# Altium schematic sheet SH_SW20_V1.0.SchDoc
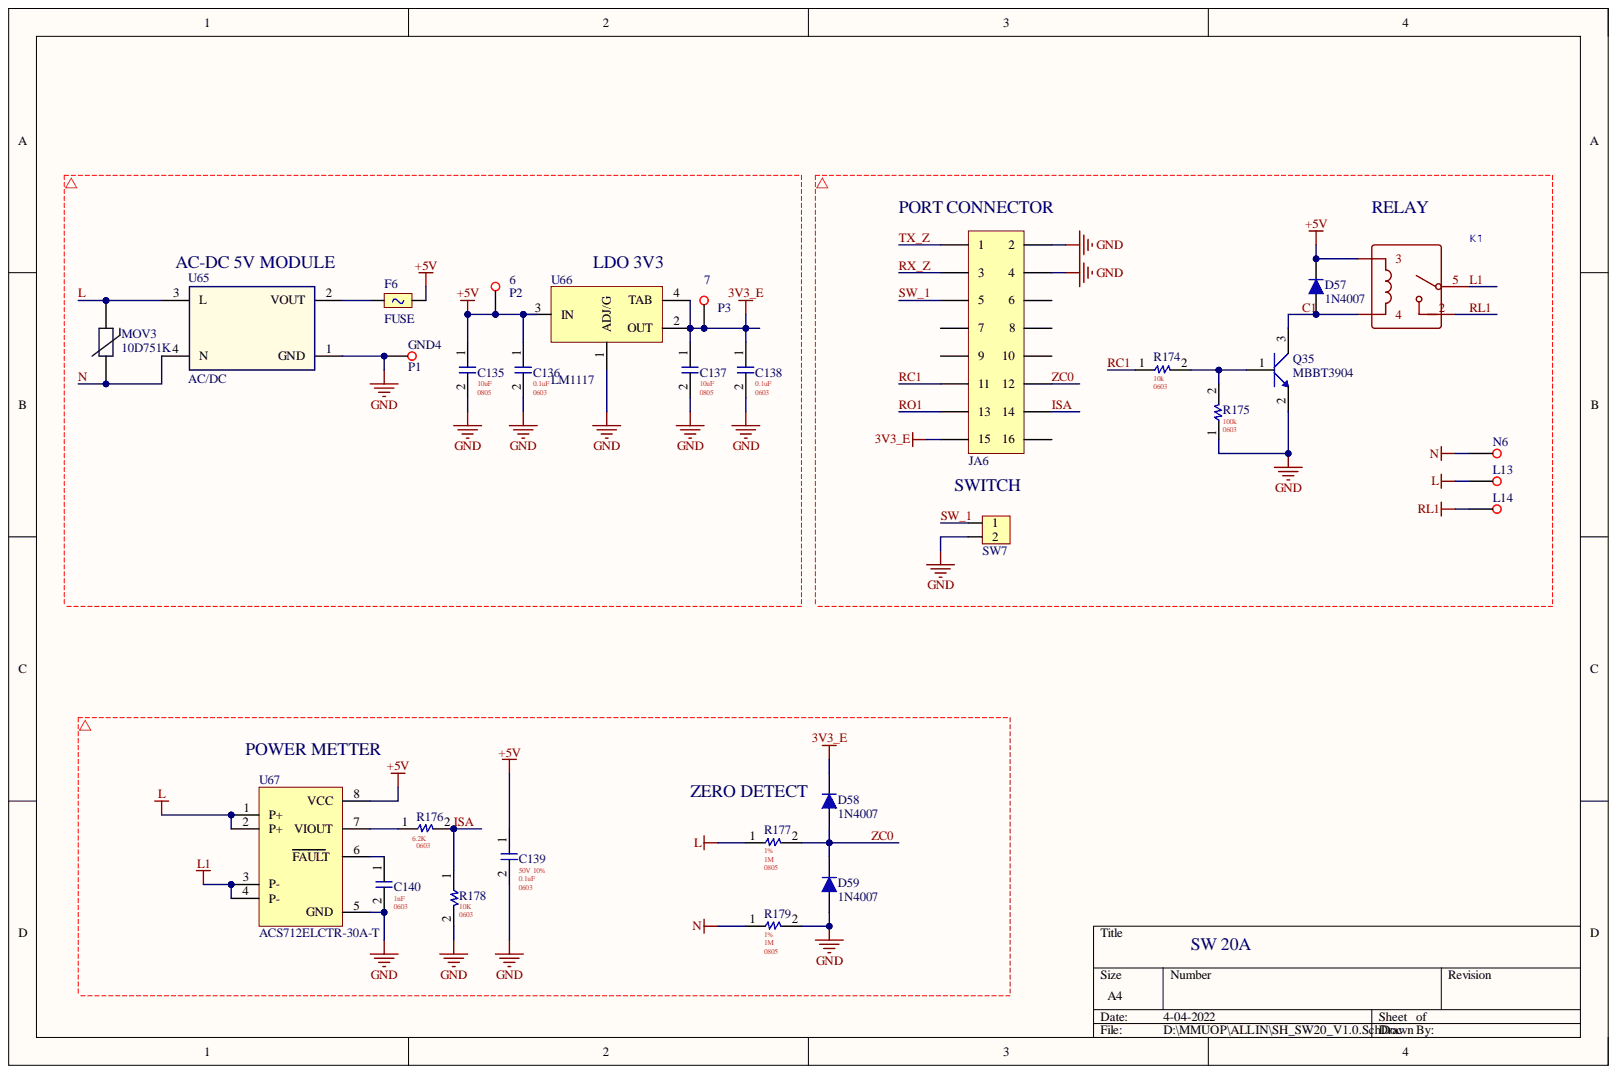
[diagram p0: SH_SW20_V1.0.SchDoc]

## Parts
6  P2  [TEXT_POINT]
7  P3  [TEXT_POINT]
C135  10uF  FP: 0805  [0805 C]
C136  0.1uF  FP: 0603  [0603 C]
C137  10uF  FP: 0805  [0805 C]
C138  0.1uF  FP: 0603  [0603 C]
C139  0.1uF  FP: 0603  VOLTAGE + TOLE: 50V 10%  [0603 C]
C140  1nF  FP: 0603  [0603 C]
D57  1N4007  [DO214AC]
D58  1N4007  [SOD-123]
D59  1N4007  [SOD-123]
F6  FUSE  [1812]
GND4  P1  [TEXT_POINT]
JA6  rao doi  [P2X8 - 2.0mm - female - SMD]  (value not drawn)
K1  (no value)  [SLA-5VDC-SL-A]
L13  P1  [P1]  (value not drawn)
L14  P1  [P1]  (value not drawn)
MOV3  10D751K  [MOV]
N6  P1  [P1]  (value not drawn)
Q35  MBBT3904  [SOT 23-3]
R174  FP: 0603  [0603 R]  (hidden comment: 10K)
R175  100k  FP: 0603  [0603 R]
R176  value 6.2K  FP: 0603  [0603 R]  (hidden comment: 8.2k)
R177  value 1M  FP: 0805  VOLTAGE + TOLE: 1%  [0805 R]  (hidden comment: 1K)
R178  value 10K  FP: 0603  [0603 R]  (hidden comment: 8.2k)
R179  value 1M  FP: 0805  VOLTAGE + TOLE: 1%  [0805 R]  (hidden comment: 1K)
SW7  P2  [P2 - 2.54mm]  (value not drawn)
U65  AC/DC  [AC-DC 5V K4H24]
U66  LM1117  [SOT23-4]
U67  ACS712ELCTR-30A-T  [SOIC 8]

## Nets
3V3_E: 7.1 C137.1 C138.1 D58.2 JA6.15 U66.2(OUT) U66.4(TAB)
+5V: 6.1 C135.1 C136.1 C139.1 D57.2 F6.2 K1.3 U66.3(IN) U67.8(VCC)
GND: C135.2 C136.2 C137.2 C138.2 C139.2 C140.2 D59.1 GND4.1 JA6.2 JA6.4 Q35.2 R175.1 R178.2 R179.2 SW7.2 U65.1(GND) U66.1(ADJ/G) U67.5(GND)
C1: D57.1 K1.4 Q35.3
ISA: JA6.14 R176.2 R178.1
L: L13.1 MOV3.2 R177.1 U65.3(L) U67.1(P+) U67.2(P+)
L1: K1.5 U67.3(P-) U67.4(P-)
N: MOV3.1 N6.1 R179.1 U65.4(N)
RC1: JA6.11 R174.1
RL1: K1.2 L14.1
RO1: JA6.13
RX_Z: JA6.3
SW_1: JA6.5 SW7.1
TX_Z: JA6.1
ZC0: D58.1 D59.2 JA6.12 R177.2
NetC140_1: C140.1 U67.6(FAULT)
NetF6_1: F6.1 U65.2(VOUT)
NetQ35_1: Q35.1 R174.2 R175.2
NetR176_1: R176.1 U67.7(VIOUT)

Not connected: JA6 6 7 8 9 10 16

## Library data of the parts
6 7 = P1: Component Kind: Standard; Library Name: Kienlib.SchLib
C135 C137 = 0805 10uF CER: CAP CER 10uF 50V 10% 0805 SMD; VOLTAGE + TOLE: 50V 10%; Component Kind: Standard; ComponentLink1Description: New link; DATE: 04-Mar-21; Library Name: Capacitor.SchLib; PACKAGE: SMD; VALUE: 10uF; CREATOR: MMUOP
C136 C138 = 0603 0.1uF CER: CAP CER 0.1uF 50V 10% 0603 SMD; VOLTAGE + TOLE: 50V 10%; Component Kind: Standard; ComponentLink1Description: New link; DATE: 04-Mar-21; Library Name: Capacitor.SchLib; PACKAGE: SMD; VALUE: 0.1uF; CREATOR: MMUOP
C139 = 0603 0.1uF CER: CAP CER 0.1uF 50V 10% 0603 SMD; Component Kind: Standard; ComponentLink1Description: New link; DATE: 04-Mar-21; Library Name: Capacitor.SchLib; PACKAGE: SMD; VALUE: 0.1uF; CREATOR: MMUOP
C140 = 0603 1nF CER: CAP CER 1nF 50V 10% 0603 SMD; VOLTAGE + TOLE: 50V 10%; Component Kind: Standard; ComponentLink1Description: New link; DATE: 04-Mar-21; Library Name: Capacitor.SchLib; PACKAGE: SMD; VALUE: 1nF; CREATOR: MMUOP
D57-D59 = Diode schottky: Component Kind: Standard; Library Name: Kienlib.SchLib
JA6 = P2x8: Component Kind: Standard; Library Name: Kienlib.SchLib
K1 = SLA-5VDC-SL-A_C688869: General Purpose 5VDC SPST-NO Non Latching Through Hole Power Relays ROHS; Component Kind: Standard
MOV3 = VARISTOR: 750V; Component Kind: Standard; Library Name: Kienlib.SchLib
Q35 = TRANS NPN: Bipolar (BJT) Transistor NPN 40 V 200 mA 300MHz 350 mW Surface Mount SOT-23-3 (TO-236); Component Kind: Standard; Library Name: Kienlib.SchLib
R174 = 0603 10K 1%: 10k 1% 0603 SMD; Component Kind: Standard; ComponentLink1Description: New link; DATE: 04-Mar-21; Library Name: Res.SchLib; PACKAGE: SMD; VALUE: 10k; VOLTAGE + TOLE: 1%; CREATOR: MMUOP
R175 = 0603 100K 1%: 100k 1% 0603 SMD; Component Kind: Standard; ComponentLink1Description: New link; DATE: 04-Mar-21; Library Name: Res.SchLib; PACKAGE: SMD; VALUE: 100k; VOLTAGE + TOLE: 1%; CREATOR: MMUOP
R176 R178 = 0603 8.2k 1%: 8.2k 1% 0603 SMD; Component Kind: Standard; ComponentLink1Description: New link; DATE: 04-Mar-21; Library Name: Res.SchLib; PACKAGE: SMD; VOLTAGE + TOLE: 1%; CREATOR: MMUOP
R177 R179 = RES 0805 1K 1%: 1M 1% 0805 SMD; Component Kind: Standard; ComponentLink1Description: New link; DATE: 04-Mar-21; Library Name: Res.SchLib; PACKAGE: SMD; CREATOR: MMUOP
U67 = ACS71240: ACS712ELCTR-20A  SOIC 8; Component Kind: Standard; ComponentLink1Description: New link; ComponentLink1URL: https://banlinhkiendientu.vn/acs712t/; Library Name: Kienlib.SchLib
F6 = FUSE: Component Kind: Standard; Library Name: Kienlib.SchLib
GND4 L13 L14 N6 = P1: Component Kind: Standard; Library Name: Kienlib.SchLib
SW7 = P2: Component Kind: Standard; Library Name: Kienlib.SchLib
U65 = AC/DC: Component Kind: Standard; Library Name: Kienlib.SchLib
U66 = LM1117: Component Kind: Standard; Library Name: Kienlib.SchLib
